# Altium schematic sheet SB_Valve_3_v1.1.SchDoc(SB_Valve_3_v1.1)
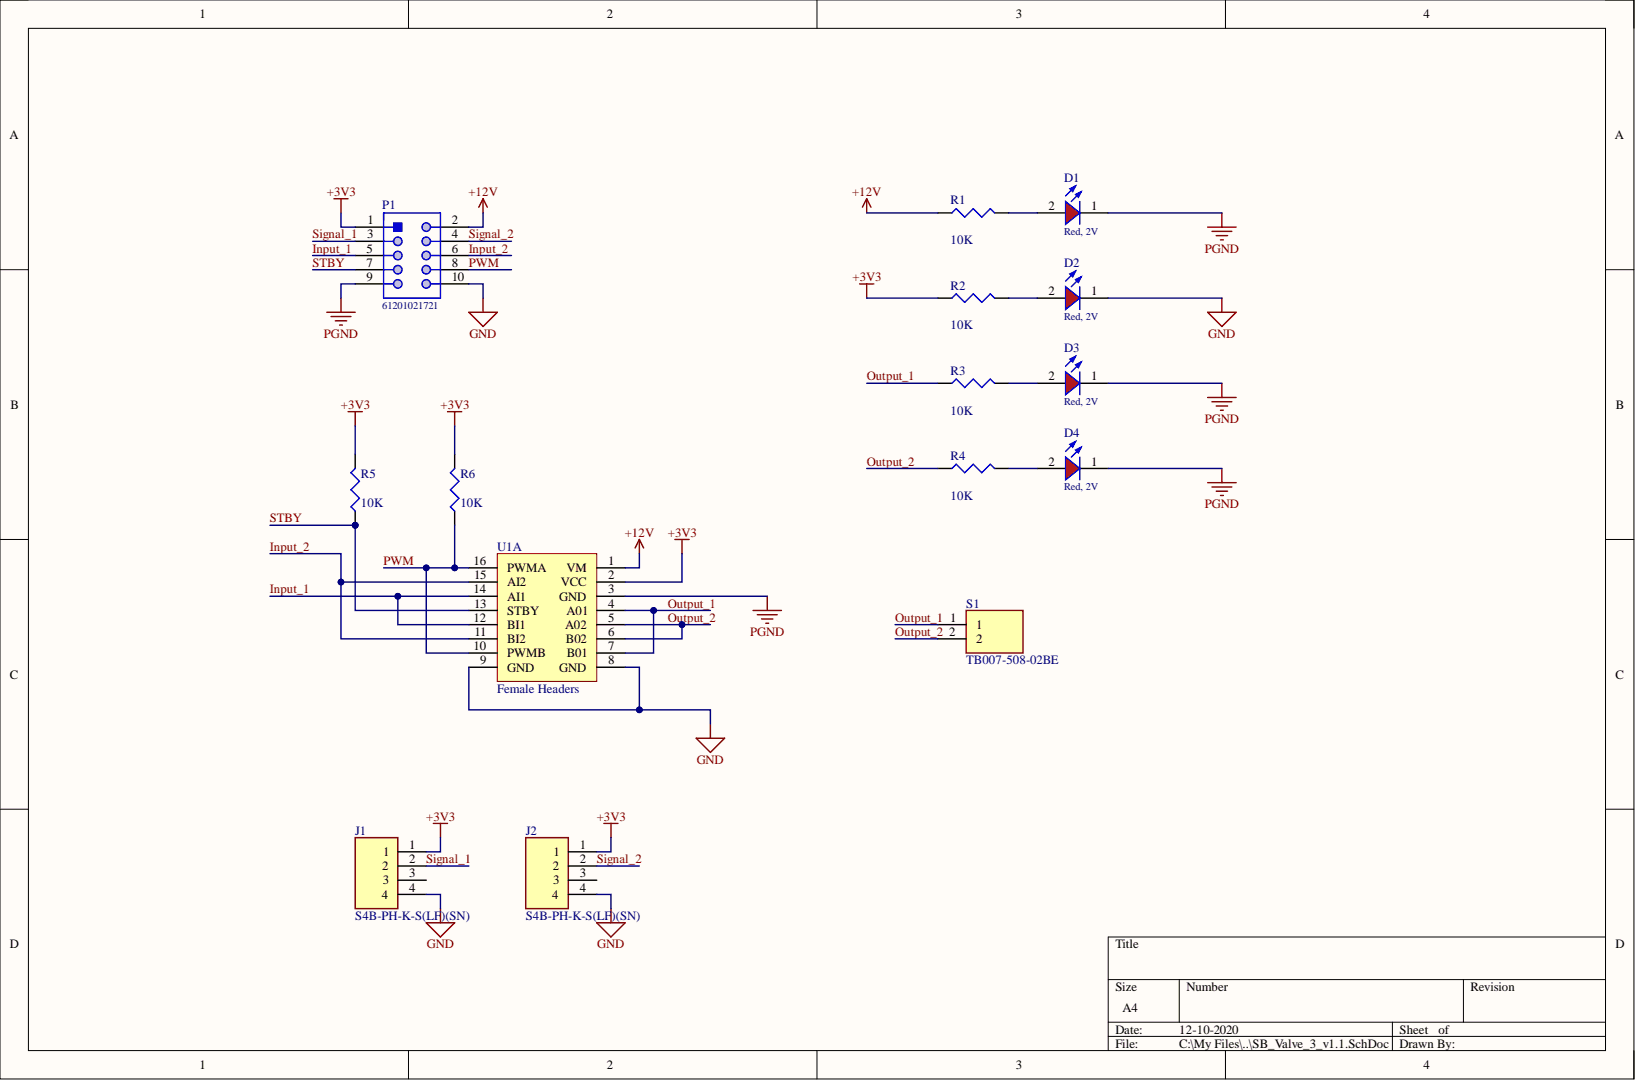
[diagram p0: SB_Valve_3_v1.1.SchDoc]

## Parts
D1  Red, 2V  Forward Voltage typ.: 2V  [WL-SMSW_0603_Rectangular]
D2  Red, 2V  Forward Voltage typ.: 2V  [WL-SMSW_0603_Rectangular]
D3  Red, 2V  Forward Voltage typ.: 2V  [WL-SMSW_0603_Rectangular]
D4  Red, 2V  Forward Voltage typ.: 2V  [WL-SMSW_0603_Rectangular]
J1  S4B-PH-K-S(LF)(SN)  [JST_S4B-PH-K-S(LF)(SN)]
J2  S4B-PH-K-S(LF)(SN)  [JST_S4B-PH-K-S(LF)(SN)]
P1  61201021721  [61201021721]
R1  value 10K  [J1-0603]  (hidden comment: R4)
R2  value 10K  [J1-0603]  (hidden comment: R3)
R3  value 10K  [J1-0603]  (hidden comment: R5)
R4  value 10K  [J1-0603]  (hidden comment: R6)
R5  value 10K  [J1-0603]  (hidden comment: R2)
R6  value 10K  [J1-0603]  (hidden comment: R1)
S1  TB007-508-02BE  [CUI_TB007-508-02BE]
U1  Female Headers  [TB6612FNG]  (part A)

## Nets
+3V3: J1.1 J2.1 P1.1 R2.1 R5.2 R6.2 U1.2(VCC)
+12V: P1.2 R1.1 U1.1(VM)
GND: D2.1 J1.4 J2.4 P1.10 U1.8(GND) U1.9(GND)
PGND: D1.1 D3.1 D4.1 P1.9 U1.3(GND)
Input_1: P1.5 U1.12(BI1) U1.14(AI1)
Input_2: P1.6 U1.11(BI2) U1.15(AI2)
Output_1: R3.1 S1.1 U1.4(A01) U1.7(B01)
Output_2: R4.1 S1.2 U1.5(A02) U1.6(B02)
PWM: P1.8 R6.1 U1.10(PWMB) U1.16(PWMA)
Signal_1: J1.2 P1.3
Signal_2: J2.2 P1.4
STBY: P1.7 R5.1 U1.13(STBY)
NetD1_2: D1.2 R1.2
NetD2_2: D2.2 R2.2
NetD3_2: D3.2 R3.2
NetD4_2: D4.2 R4.2

Not connected: J1 3; J2 3

## Library data of the parts
D1-D4 = 155060RS75300: WL-SMSW SMT Mono-color Side View Waterclear, size 0603, Red, 2V, 140deg; Manufacturer: Wurth Elektronik; Chip Technology: AlInGaP; Dominant Wavelength typ.: 625nm; Luminous Intensity typ.: 150mcd; Peak Wavelength typ.: 630nm; Component Kind: Standard; ComponentLink1Description: Manufacturer; ComponentLink1URL: http://www.we-online.de; ComponentLink2Description: Datasheet; ComponentLink2URL: https://www.we-online.com/catalog/datasheet/155060RS75300.pdf; Emitting Color: Red; Library Name: WL-SMSW (rev20b).IntLib; Manufacturer Part Number: 155060RS75300; Mounting Technology: SMT; Operating Temperature: -40 to +85degC; Packaging: Tape and Reel; Published Date: October 2020; Size: 0603; Viewing Angle: 140deg
J1 J2 = S4B-PH-K-S(LF)(SN): Connector Header Through Hole, Right Angle 4 position 0.079 _2.00mm_; MF: JST Sales; MP: S4B-PH-K-S_LF__SN_; Availability: Unavailable; Component Kind: Standard; Library Name: S4B-PH-K-S_LF__SN_.IntLib; Package: None; Price: None; SnapEDA_Link: https://www.snapeda.com/parts/S4B-PH-K-S%28LF%29%28SN%29/JST%20Sales%20America%20Inc./view-part/591324/?ref=snap
P1 = CMP-1502-00021-1: Male Box Header WR-BHD, THT, Angled, pitch 2.54 mm, 10 pins; Manufacturer: Wurth Elektronik; Contact Resistance: 20mOhm; Pin Number: 10; Pitch: 2.54mm; Withstanding Voltage: 500VAC; Working Voltage: 250VAC; Component Kind: Standard; Datasheet URL: https://katalog.we-online.de/em/datasheet/6120xx21721.pdf; Gender: Male; Library Name: Altium Content Vault; Manufacturer URL: http://www.we-online.de; Mounting Technology: THT; Operating Temperature: -40to125degC; Orientation: Angled; Package Reference: 61201021721; Packaging: Tray; Part Number: 61201021721; Published Date: August2018; Publisher: WurthElektronik
R1-R6 = Res3: Resistor; Component Kind: Standard; LatestRevisionDate: 29-May-2009; LatestRevisionNote: IPC-7351 Footprint Added.; Library Name: Miscellaneous Devices.IntLib; PackageDescription: Chip Resistor; PackageReference: J1-0603; Published: 8-Jun-2000; Signal Integrity: Res; Simulation: RESISTOR; Publisher: Altium Limited
S1 = TB007-508-02BE: 2~24 Poles, Screw Type, Horizontal, 5.08 Pitch, 24~12 _AWG_, Terminal Block Connector; MF: CUI Devices; Availability: Unavailable; Component Kind: Standard; Library Name: TB007-508-02BE.IntLib; MP: TB007-508-02BE; Package: None; Price: None; SnapEDA_Link: https://www.snapeda.com/parts/TB007-508-02BE/CUI%20Devices/view-part/3591212/?ref=snap
U1 = TB6612FNG Female: Motor Driver; Component Kind: Standard; Library Name: SectionE_BreakOut.SchLib
